# Altium schematic sheet BLDC Motor Reversal.SchDoc("BLDC Motor Reversal")
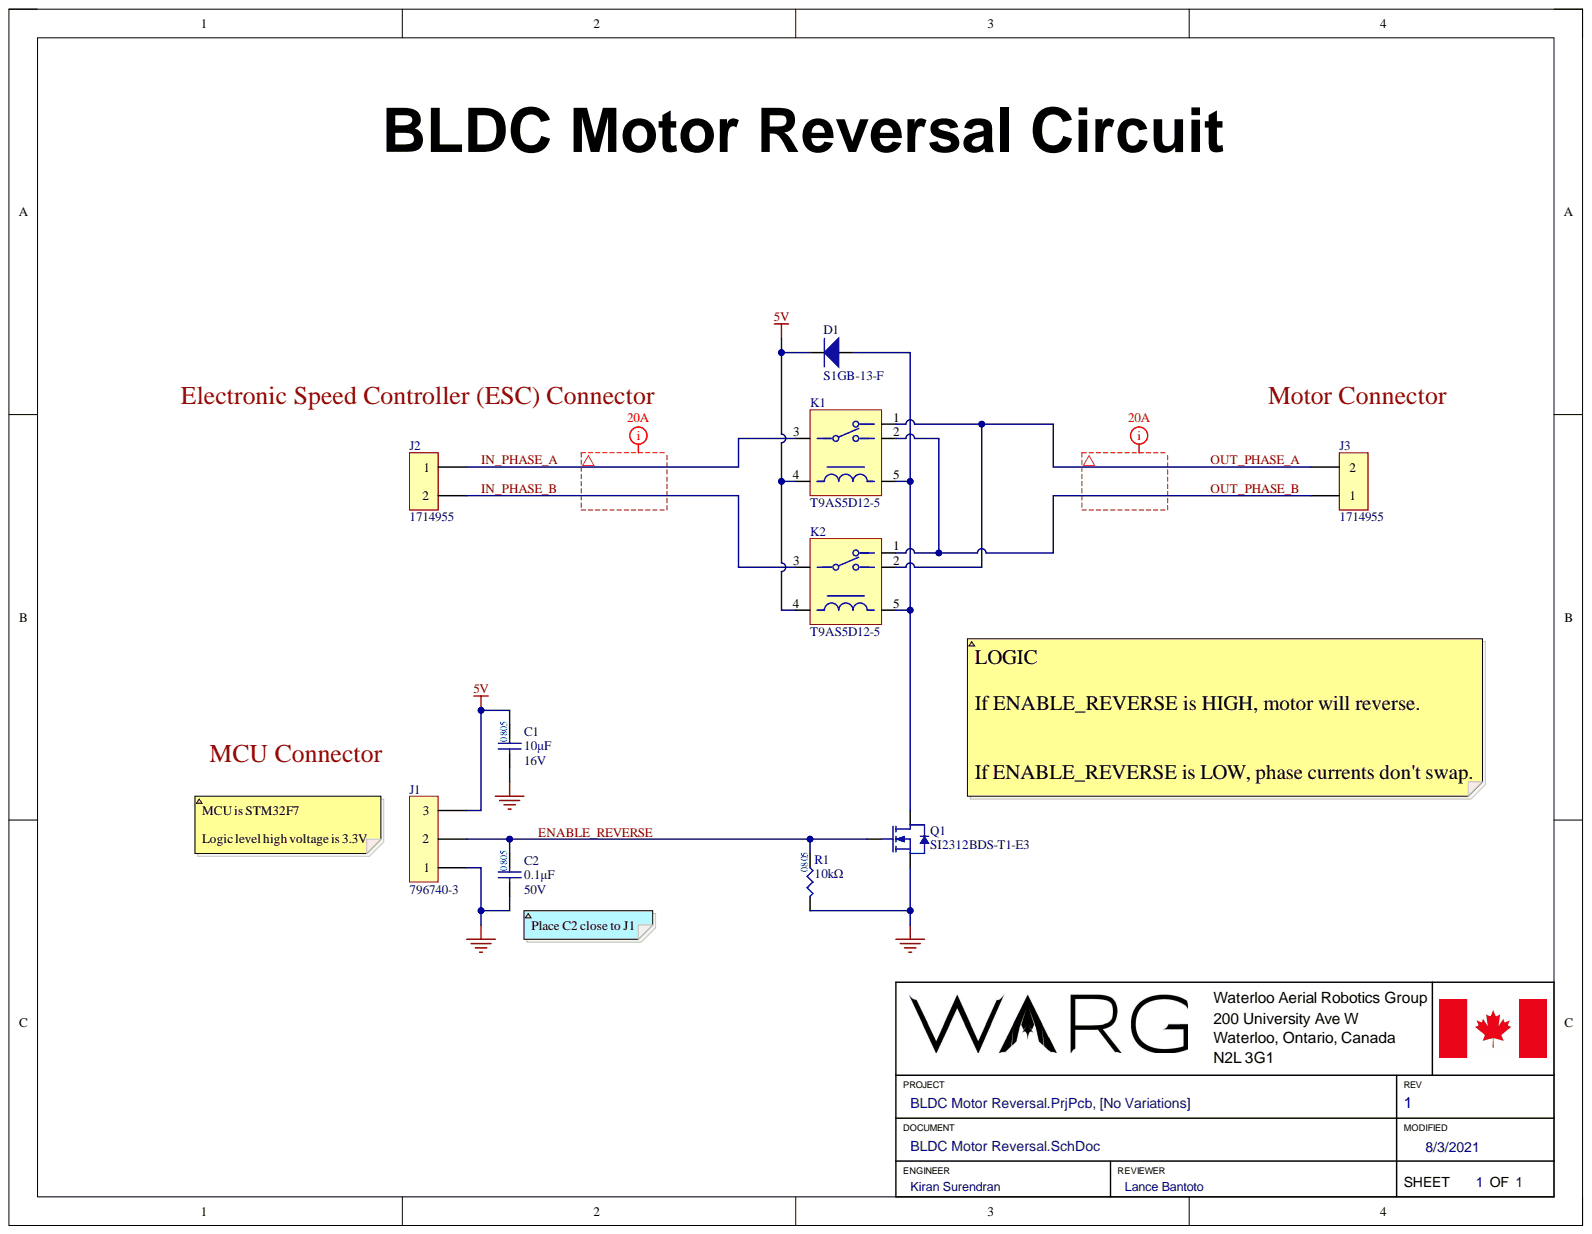
[diagram p0: BLDC Motor Reversal.SchDoc]

## Parts
C1  value 10μF  Case/Package: 0805  Voltage Rating: 16V  [C0805C106K4PACTU]  (hidden comment: C0805C106K4PACTU)
C2  value 0.1μF  Package: 0805  Voltage Rating: 50V  [C0805C104K5RACTU]  (hidden comment: C0805C104K5RACTU)
D1  S1GB-13-F  [S1GB-13-F]
J1  796740-3  [796740-3]
J2  1714955  [1714955]
J3  1714955  [1714955]
K1  T9AS5D12-5  [T9AS5D12-5]
K2  T9AS5D12-5  [T9AS5D12-5]
Q1  (no value)  [SI2312BDS-T1-E3]
R1  value 10kΩ  Package: 0805  [RC0805JR-0710KL]  (hidden comment: RC0805JR-0710KL)

## Nets
5V: C1.2 D1.1 J1.3 K1.4 K2.4
GND: C1.1 C2.1 J1.1 Q1.2 R1.1
ENABLE_REVERSE: C2.2 J1.2 Q1.1 R1.2
IN_PHASE_A: J2.1 K1.3
IN_PHASE_B: J2.2 K2.3
OUT_PHASE_A: J3.2 K1.1 K2.2
OUT_PHASE_B: J3.1 K1.2 K2.1
NetD1_2: D1.2 K1.5 K2.5 Q1.3

## Library data of the parts
C1 = C0805C106K4PACTU: CAP CER 10UF 16V X5R 0805; Manufacturer 1: KEMET; Component Kind: Standard; Library Name: BLDC_Motor_Lib.SchLib; Manufacturer Part Number 1: C0805C106K4PACTU; Supplier 1: Digi-Key; Supplier Part Number 1: 399-8012-1-ND
C2 = C0805C104K5RACTU: CAP CER 0.1UF 50V X7R 0805; Manufacturer 1: KEMET; Component Kind: Standard; Library Name: BLDC_Motor_Lib.SchLib; Manufacturer Part Number 1: C0805C104K5RACTU; Supplier 1: Digi-Key; Supplier Part Number 1: 399-1170-1-ND
D1 = S1GB-13-F: DIODE GEN PURP 400V 1A SMB; Manufacturer 1: Diodes Incorporated; IFSM: 8.0A; VRRM: 325V; Component Kind: Standard; Library Name: BLDC_Motor_SchLib.SchLib; Manufacturer Part Number 1: S1GB-13-F; Supplier 1: Digi-Key; Supplier Part Number 1: S1GB-FDICT-ND
J1 = 796740-3: TERM BLK 3P SIDE ENT 6.35MM PCB; Manufacturer 1: TE Connectivity AMP Connectors; Component Kind: Standard; Library Name: BLDC_Motor_Lib.SchLib; Manufacturer Part Number 1: 796740-3; Supplier 1: Digi-Key; Supplier Part Number 1: A98276-ND
J2 J3 = 1714955: TERM BLK 2P SIDE ENT 6.35MM PCB; Manufacturer: Phoenix Contact; Manufacturer Part Number: 1714968; Current Rating: 30A; Voltage Rating: 300V; Component Kind: Standard; Library Name: BLDC_Motor_SchLib.SchLib; Supplier 1: Digi-Key; Supplier Part Number 1: 277-1269-ND
K1 K2 = T9AS5D12-5: RELAY GEN PURPOSE SPDT 20A 5V; Manufacturer 1: TE Connectivity Potter & Brumfield Relays; Coil Current: 200mA; Contact Voltage Rating (AC): 277V; Current Rating: 30A; Coil Voltage (DC): 5V; Component Kind: Standard; Contact Current Rating: 20A; Library Name: BLDC_Motor_Lib.SchLib; Manufacturer Part Number 1: T9AS5D12-5; Supplier 1: Digi-Key; Supplier Part Number 1: T9AS5D12-5-ND; Voltage Rating (DC): 5V
Q1 = SI2312BDS-T1-E3: MOSFET N-CH 20V 3.9A SOT23-3; Manufacturer 1: Vishay Siliconix; Component Kind: Standard; Library Name: BLDC_Motor_Lib.SchLib; Manufacturer Part Number 1: SI2312BDS-T1-E3; Supplier 1: Digi-Key; Supplier Part Number 1: SI2312BDS-T1-E3CT-ND
R1 = RC0805JR-0710KL: RES SMD 10K OHM 5% 1/8W 0805; Manufacturer 1: Yageo; Component Kind: Standard; Library Name: BLDC_Motor_Lib.SchLib; Manufacturer Part Number 1: RC0805JR-0710KL; Supplier 1: Digi-Key; Supplier Part Number 1: 311-10KARCT-ND; Tolerance: 5%
